# Altium schematic sheet SCH1.SchDoc
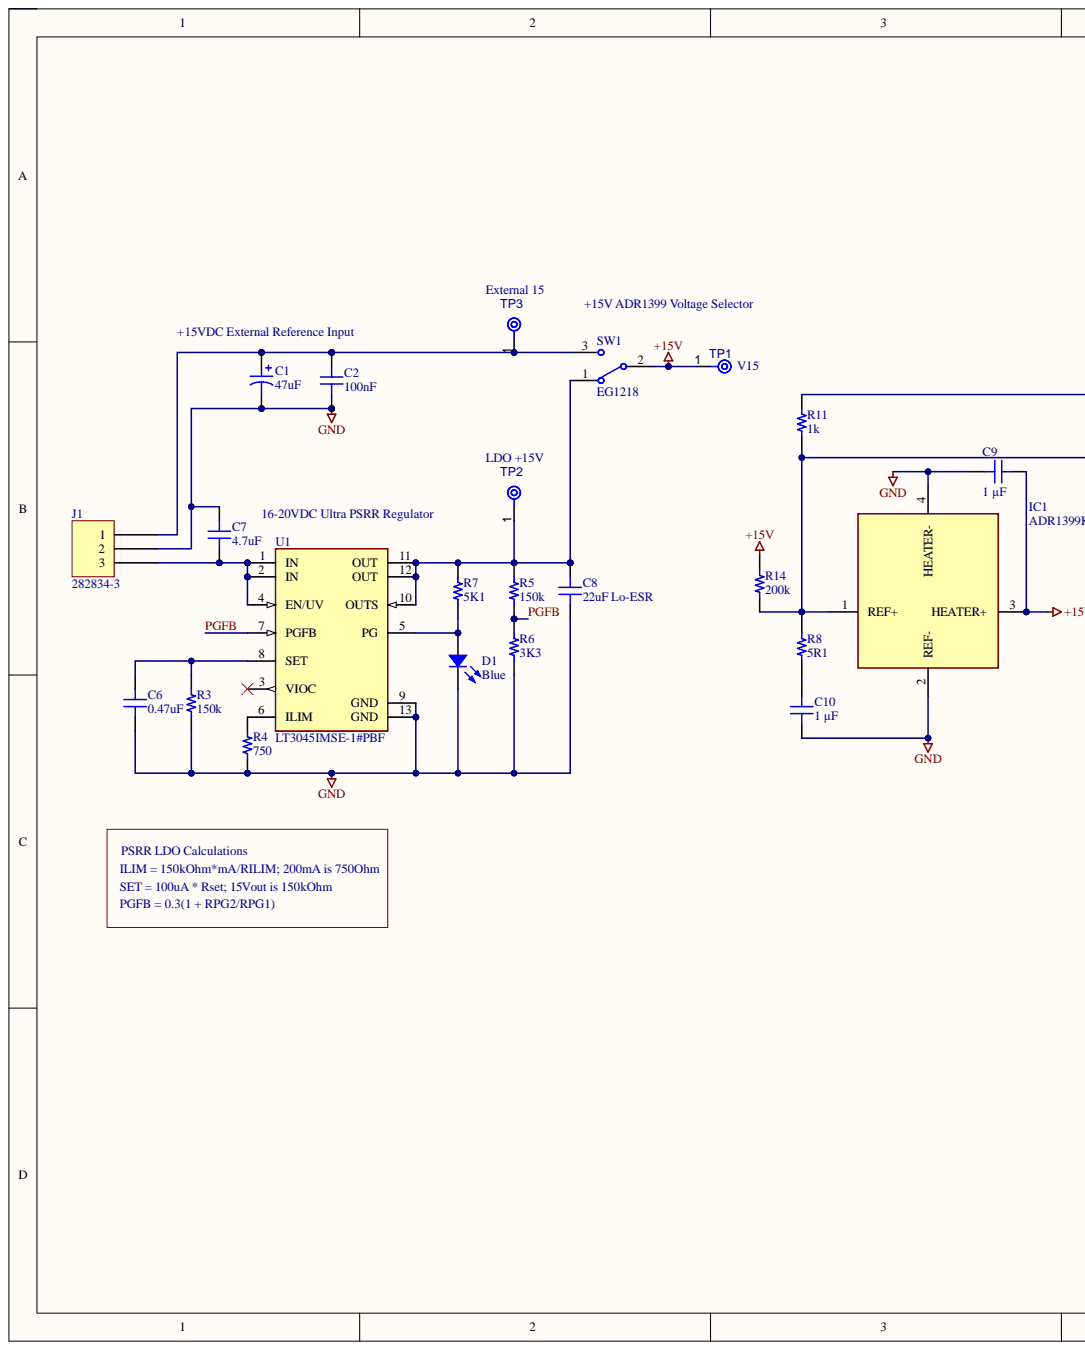
[diagram p0: SCH1.SchDoc, left]
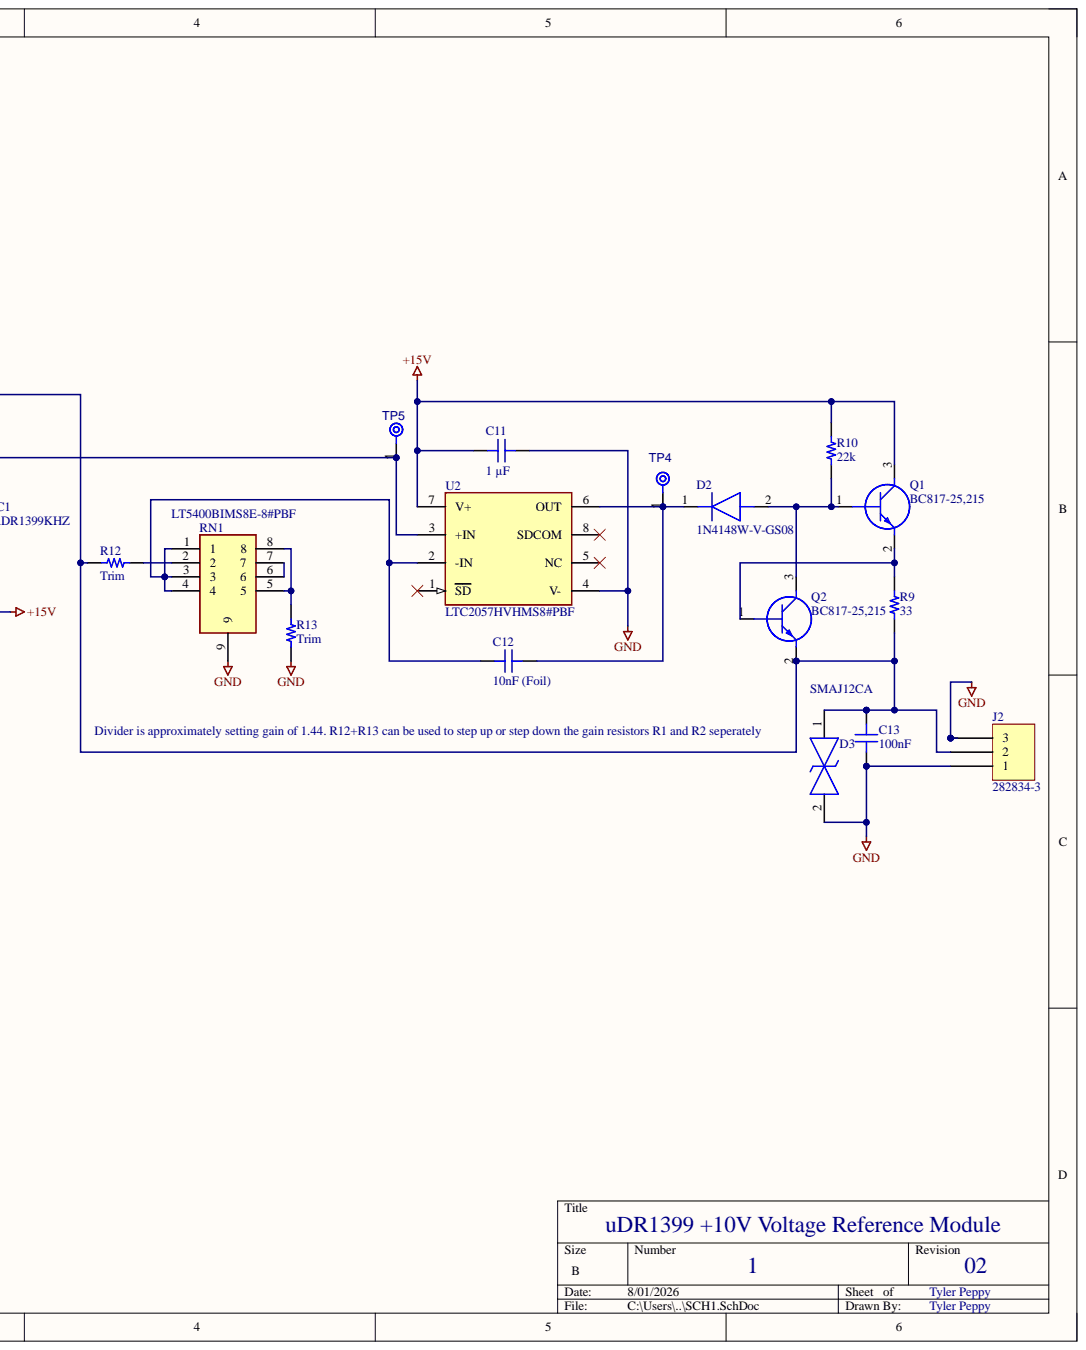
[diagram p1: SCH1.SchDoc, right]

## Parts
C1  47uF  [CAP ALSMD 8.3MM H10.5MM]
C2  Capacitance: 100nF  [CAP 0603_1608]  (hidden comment: 4.7 µF)
C6  Capacitance: 0.47uF  [CAP 0603_1608]  (hidden comment: 4.7 µF)
C7  Capacitance: 4.7uF  [CAP 0603_1608]  (hidden comment: 4.7 µF)
C8  Capacitance: 22uF Lo-ESR  [CAP 0603_1608]  (hidden comment: 4.7 µF)
C9  Capacitance: 1 µF  [CAP 0603_1608]  (hidden comment: 4.7 µF)
C10  Capacitance: 1 µF  [CAP 0603_1608]  (hidden comment: 4.7 µF)
C11  Capacitance: 1 µF  [CAP 0603_1608]  (hidden comment: 4.7 µF)
C12  Capacitance: 10nF (Foil)  [CAP 0603_1608]  (hidden comment: 4.7 µF)
C13  Capacitance: 100nF  [CAP 0603_1608]  (hidden comment: 4.7 µF)
D1  Blue  [LED 1206_3216 YELLOW]
D2  1N4148W-V-GS08  [1N4148WVGS08]
D3  SMAJ12CA  [DIONM5127X229N]
IC1  ADR1399KHZ  [ADR1399KHZ]
J1  282834-3  [TE 282834-3]
J2  282834-3  [TE 282834-3]
Q1  BC817-25,215  [BC81725215]
Q2  BC817-25,215  [BC81725215]
R3  150k  [RES 0603_1608]
R4  750  [RES 0603_1608]
R5  150k  [RES 0603_1608]
R6  3K3  [RES 0603_1608]
R7  5K1  [RES 0603_1608]
R8  5R1  [RES 0603_1608]
R9  33  [RES 0603_1608]
R10  22k  [RES 0603_1608]
R11  1k  [RES 0603_1608]
R12  Trim  [RES 0603_1608]
R13  Trim  [RES 0603_1608]
R14  200k  [RES 0603_1608]
RN1  LT5400BIMS8E-8#PBF  [SOP65P490X110-9N]
SW1  EG1218  [ESWI-EG1218_V]
TP1  TPAD6R  [TP06R]  (value not drawn)  (no BOM)
TP2  TPAD6R  [TP06R]  (value not drawn)  (no BOM)
TP3  TPAD6R  [TP06R]  (value not drawn)  (no BOM)
TP4  TPAD6R  [TP06R]  (value not drawn)  (no BOM)
TP5  TPAD6R  [TP06R]  (value not drawn)  (no BOM)
U1  LT3045IMSE-1#PBF  [FP-MSE-12-05-08-1666-MFG]
U2  LTC2057HVHMS8#PBF  [FP-MS8-8-05-08-1660-IPC_C]

## Nets
+15V: C9.2 C11.1 IC1.3(HEATER+) Q1.3 R10.2 R14.2 SW1.2 TP1.1 U2.7(V+)
GND: C1.2 C2.2 C6.2 C7.1 C8.2 C9.1 C10.1 C11.2 C13.1 D1.2 D3.2 IC1.2(REF-) IC1.4(HEATER-) J1.2 J2.1 J2.3 R3.1 R4.1 R6.1 R13.1 RN1.9 U1.9(GND) U1.13(GND) U2.4(V-)
PGFB: R5.1 R6.2 U1.7(PGFB)
NetC1_1: C1.1 C2.1 J1.1 SW1.3 TP3.1
NetC6_1: C6.1 R3.2 U1.8(SET)
NetC7_2: C7.2 J1.3 U1.1(IN) U1.2(IN) U1.4(EN/UV)
NetC8_1: C8.1 R5.2 R7.2 SW1.1 TP2.1 U1.10(OUTS) U1.11(OUT) U1.12(OUT)
NetC10_2: C10.2 R8.1
NetC12_1: C12.1 RN1.1 RN1.3 RN1.4 U2.2(-IN)
NetC12_2: C12.2 D2.1 TP4.1 U2.6(OUT)
NetC13_2: C13.2 D3.1 J2.2 Q2.2 R9.1 R11.2 R12.2
NetD1_1: D1.1 R7.1 U1.5(PG)
NetD2_2: D2.2 Q1.1 Q2.3 R10.1
NetIC1_1: IC1.1(REF+) R8.2 R11.1 R14.1 TP5.1 U2.3(+IN)
NetQ1_2: Q1.2 Q2.1 R9.2
NetR4_2: R4.2 U1.6(ILIM)
NetR12_1: R12.1 RN1.2
NetR13_2: R13.2 RN1.5 RN1.8
NetRN1_6: RN1.6 RN1.7

Not connected: U1 3(VIOC); U2 1(SD) 5(NC) 8(SDCOM)

## Library data of the parts
C1 = EMZS350ARA471MHA0G: CAP ALUM 470UF 20% 35V SMD; manufacturer: United Chemi-Con; Capacitance: 470 µF; Database Table Name: Capacitors - Aluminum - Electrolytic SMT; ESR (Equivalent Series Resistance): 80mOhm @ 100kHz; Lifetime @ Temp.: 2000 Hrs @ 105°C; Polarization: Polar; Ripple Current @ High Frequency: 850 mA @ 100 kHz; Ripple Current @ Low Frequency: 425 mA @ 120 Hz; Surface Mount Land Size: 0.327" L x 0.327" W (8.30mm x 8.30mm); value: 470 µF; Applications: Automotive; Component Kind: Standard; componentlink1description: Datasheet; componentlink1url: //media.digikey.com/pdf/Data%20Sheets/United%20Chemi-Con%20PDFs/MZS_Series_NPI.pdf; componentlink2description: DigiKey Link; componentlink2url: https://www.digikey.com/en/products/detail/united-chemi-con/EMZS350ARA471MHA0G/8637079; Device Package: Radial, Can - SMD; Height - Seated (Max): 0.413" (10.50mm); lastupdated: 6/3/2024 8:15:48 PM; Library Name: Celestial Altium Library - altium_library.DbLib; Lifecycle Status: Active; Minimum Order: 1; Mounting Type: Surface Mount; Operating Temperature: -55°C ~ 105°C; Package / Case: Radial, Can - SMD; packaging: TapeAndReel; Part Number: EMZS350ARA471MHA0G; Part Status: Active; partid: 7526; price: 0.40; Ratings: AEC-Q200; series: Alchip™- MZS; Size / Dimension: 0.315" Dia (8.00mm); Supplier 1: DigiKey; Supplier Part Number 1: 565-5123-1-ND; Tolerance: ±20%; Voltage - Rated: 35 V
C2 C6-C13 = CL10A475KA8NQNC: CAP CER 4.7UF 25V X5R 0603; manufacturer: Samsung Electro-Mechanics; Database Table Name: Capacitors - Ceramic - 0603; Tolerance: ±10%; Applications: General Purpose; Component Kind: Standard; componentlink1description: Datasheet; componentlink1url: http://www.samsungsem.com/kr/support/product-search/mlcc/CL10A475KA8NQNC.jsp; componentlink2description: DigiKey Link; componentlink2url: https://www.digikey.com/en/products/detail/samsung-electro-mechanics/CL10A475KA8NQNC/3887558; Device Package: 0603 (1608 Metric); lastupdated: 2/9/2024 8:10:30 PM; Library Name: Celestial Altium Library - altium_library.DbLib; Lifecycle Status: Active; Minimum Order: 1; Mounting Type: Surface Mount, MLCC; Operating Temperature: -55°C ~ 85°C; Package / Case: 0603 (1608 Metric); packaging: TapeAndReel; Part Number: CL10A475KA8NQNC; Part Status: Active; partid: 2349; price: 0.06; series: CL; Size / Dimension: 0.063" L x 0.031" W (1.60mm x 0.80mm); Supplier 1: DigiKey; Supplier Part Number 1: 1276-1900-1-ND; Temperature Coefficient: X5R; Thickness (Max): 0.035" (0.90mm); value: 4.7 µF; Voltage - Rated: 25V
D1 = 5990240007F: LED YELLOW 1206 SMD; Manufacturer: Dialight; Configuration: Standard; Current - Test: 20mA; Database Table Name: LED - Indication; Lens Color: 0.80mm; Lens Size: 2.00mm x 1.60mm; Lens Style: Rectangle with Flat Top; Millicandela Rating: 126mcd; Viewing Angle: 140°; Voltage - Forward (Vf) (Typ): 2.1V; Wavelength - Dominant: 590nm; Color: Yellow; Component Kind: Standard; ComponentLink1Description: Datasheet; ComponentLink1URL: http://www.dialightsignalsandcomponents.com/Assets/Brochures_And_Catalogs/Indication/CBI_SMD_new/Dialight_CBI_data_599-1206_Apr2018.pdf; ComponentLink2Description: DigiKey Link; ComponentLink2URL: https://www.digikey.com/en/products/detail/dialight/5990240007F/9385418; Device Package: 1206 (3216 Metric); Height (Max): 0.80mm; LastUpdated: 6/3/2024 8:01:38 PM; Library Name: Celestial Altium Library - altium_library.DbLib; Lifecycle Status: Active; Minimum Order: 1; Mounting Type: Surface Mount; Package / Case: 1206 (3216 Metric); Packaging: TapeAndReel; Part Number: 5990240007F; Part Status: Active; PartId: 1; Price: 0.04; Series: 599; Size / Dimension: 3.20mm L x 1.60mm W; Supplier 1: DigiKey; Supplier Device Package: 1206; Supplier Part Number 1: 350-4363-1-ND; Value: Yellow
D2 = 1N4148W-V-GS08: Diode; Manufacturer_Name: Vishay; Arrow Part Number: 1N4148W-V-GS08; Arrow Price/Stock: https://www.arrow.com/en/products/1n4148w-v-gs08/vishay; Component Kind: Standard; Datasheet Link: https://us.rs-online.com/m/d/a2789c8794cb0c42ced29f2dd8571f3c.pdf; Height: 1.35mm; Manufacturer_Part_Number: 1N4148W-V-GS08; Mouser Part Number: 625-1N4148W-V; Mouser Price/Stock: https://www.mouser.co.uk/ProductDetail/Vishay-Semiconductors/1N4148W-V-GS08?qs=TNTIDjy6APrS%2Fdgq1RSs3A%3D%3D
D3 = SMAJ12CA: TVS Diode (Bi-directional); Manufacturer_Name: Bourns; Arrow Part Number: SMAJ12CA; Arrow Price/Stock: https://www.arrow.com/en/products/smaj12ca/bourns.html?utm_currency=USD&region=nac; Component Kind: Standard; Datasheet Link: https://www.bourns.com/data/global/pdfs/SMAJ.pdf; Height: 2.29mm; Manufacturer_Part_Number: SMAJ12CA; Mouser Part Number: 652-SMAJ12CA; Mouser Price/Stock: https://www.mouser.co.uk/ProductDetail/Bourns/SMAJ12CA?qs=A3H9pljuJ8QXtI4Ziid8eA%3D%3D
IC1 = ADR1399KHZ: Integrated Circuit; Manufacturer_Name: Analog Devices; Arrow Part Number: ADR1399KHZ; Arrow Price/Stock: https://www.arrow.com/en/products/adr1399khz/analog-devices?utm_currency=USD&region=nac; Component Kind: Standard; Datasheet Link: https://www.analog.com/ADR1399/datasheet; Height: 2.667mm; Manufacturer_Part_Number: ADR1399KHZ; Mouser Part Number: 584-ADR1399KHZ; Mouser Price/Stock: https://www.mouser.co.uk/ProductDetail/Analog-Devices/ADR1399KHZ?qs=Rp5uXu7WBW%252BnLiU1PGvLXA%3D%3D
J1 J2 = 282834-3: TERM BLK 3P SIDE ENT 2.54MM PCB; Manufacturer: TE Connectivity AMP Connectors; Current: 10A; Database Table Name: Connectors - Terminal Blocks - Wire to Board; Mating Orientation: Horizontal with Board; Number of Levels: 1; Pitch: 0.100" (2.54mm); Positions Per Level: 3; Voltage: 150V; Wire Gauge: 16-30 AWG; Wire Termination: Screw - Rising Cage Clamp; Color: Green; Component Kind: Standard; ComponentLink1Description: Datasheet; ComponentLink1URL: https://www.te.com/commerce/DocumentDelivery/DDEController?Action=srchrtrv&DocNm=282834&DocType=Customer+Drawing&DocLang=English; ComponentLink2Description: DigiKey Link; ComponentLink2URL: http://digikey.com/product-detail/en/te-connectivity-amp-connectors/282834-3/A98334-ND/1153264; LastUpdated: 1/15/2020 4:15:50 AM; Library Name: Celestial Altium Library - altium_library.DbLib; Lifecycle Status: Active; Minimum Order: 1; Mounting Type: Through Hole; Packaging: Bulk; Part Number: 282834-3; Part Status: Active; Price: 2.01; Series: Buchanan; Supplier 1: DigiKey; Supplier Part Number 1: A98334-ND
Q1 Q2 = BC817-25,215: Transistor BJT NPN; Manufacturer_Name: Nexperia; Arrow Part Number: BC817-25,215; Arrow Price/Stock: https://www.arrow.com/en/products/bc817-25215/nexperia?region=nac; Component Kind: Standard; Datasheet Link: https://assets.nexperia.com/documents/data-sheet/BC817_SER.pdf; Height: 1.1mm; Manufacturer_Part_Number: BC817-25,215; Mouser Part Number: 771-BC817-25-T/R; Mouser Price/Stock: https://www.mouser.co.uk/ProductDetail/Nexperia/BC817-25215?qs=me8TqzrmIYUj67JkTBzlfg%3D%3D
R3-R14 = RL0603FR-070R01L: RES 0.01 OHM 1% 1/10W 0603; Manufacturer: Yageo; Database Table Name: Resistors - Surface Mount - 0603; Power (Watts): 0.1W, 1/10W; Resistance: 10 mOhms; Temperature Coefficient: ±1500ppm/°C; Component Kind: Standard; ComponentLink1Description: Datasheet; ComponentLink1URL: http://www.yageo.com/documents/recent/PYu-RL_Group_521_RoHS_L_2.pdf; ComponentLink2Description: DigiKey Link; ComponentLink2URL: https://www.digikey.com/en/products/detail/yageo/RL0603FR-070R01L/3885501; Composition: Thick Film; Device Package: 0603 (1608 Metric); Features: Current Sense; Height - Seated (Max): 0.022" (0.55mm); LastUpdated: 4/6/2026 7:44:01 PM; Library Name: Celestial Altium Library - altium_library.DbLib; Lifecycle Status: Active; Minimum Order: 1; Number of Terminations: 2; Operating Temperature: -55°C ~ 155°C; Package / Case: 0603 (1608 Metric); Packaging: TapeAndReel; Part Number: RL0603FR-070R01L; Part Status: Active; PartId: 4869; Price: 0.09; Ratings: AEC-Q200; Series: RL; Size / Dimension: 0.063" L x 0.031" W (1.60mm x 0.80mm); Supplier 1: DigiKey; Supplier Device Package: 0603; Supplier Part Number 1: 311-.01QCT-ND; Tolerance: ±1%
  R3 R5: Value: 150k
  R4: Value: 750
  R6: Value: 3K3
  R7: Value: 5K1
  R8: Value: 5R1
  R9: Value: 33
  R10: Value: 22k
  R11: Value: 1k
  R12 R13: Value: Trim
  R14: Value: 200k
RN1 = LT5400BIMS8E-8#PBF: Integrated Circuit; Manufacturer_Name: Analog Devices; Arrow Part Number: LT5400BIMS8E-8#PBF; Arrow Price/Stock: https://www.arrow.com/en/products/lt5400bims8e-8pbf/linear-technology; Component Kind: Standard; Datasheet Link: https://datasheet.datasheetarchive.com/originals/distributors/DKDS-14/268906.pdf; Height: 1.1mm; Manufacturer_Part_Number: LT5400BIMS8E-8#PBF; Mouser Part Number: 584-5400BIMS8E-8PBF; Mouser Price/Stock: https://www.mouser.co.uk/ProductDetail/Analog-Devices/LT5400BIMS8E-8PBF?qs=oahfZPh6IAIYY0b05xfkyw%3D%3D
SW1 = CMP-1696-00002-1: Slide Switch, 500 V, -20 to 70 degC, 3-Pin THD, RoHS; Case\Package: SOT-23-5; Dropout Voltage: 300 mV; Max Input Voltage: 8 V; Max Ouput Current: 150 mA; Max Power Dissipation: 250 mW; Min Input Voltage: 4.3 V; Nominal Output Voltage: 3.3 V; Outputs: 1; Component Kind: Standard; ComponentLink1Description: Manufacturer URL; ComponentLink1URL: http://www.e-switch.ch/en/; ComponentLink2Description: Datasheet; ComponentLink2URL: https://www.e-switch.com/system/asset/product_line/data_sheet/119/EG.pdf; DatasheetVersion: Oct-15; Height: 1.3 mm; Length: 3 mm; Library Name: Altium Content Vault; Max Operating Temperature: 85 degC; Min Operating Temperature: -40 degC; Mount: Surface Mount; Output Current: 150 mA; Output Voltage: 3.3 V; Package Quantity: 3000; PackageDescription: 3-Pin Through Hole Device, Body 11.6 x 4 mm, Pitch 2.5 mm; PackageReference: EG1218; Packaging: Tape and Reel; Pins: 5; Power Rating: 250 mW; Radiation Hardening: No; REACH SVHC: No SVHC; RoHSCompliant: true; Width: 1.8 mm
TP1-TP5 = CMP-00028-00002-2: 0.6mm round; Pins: 1; Library Name: Tyler Peppy
U1 = CMP-09138-000004-1: IC REG LINEAR 0V 500MA 12MSOP; Manufacturer: Analog Devices; Obsolete Part: false; Accuracy Percentage: 1%; Dropout Voltage: 260mV; Max Junction Temp: +150°C; Max Supply Voltage: 20V; Min Supply Voltage: 2V; Nominal Supply Current: 0.3uA; Number Of Outputs: 1; Output Type: AdjustableProgrammable; Output Voltage: 0-15V; Standoff Height: 0.0508mm; Automotive: No; Category: IC; Component Kind: Standard; ComponentLink2Description: Datasheet; ComponentLink2URL: https://www.analog.com/media/en/technical-documentation/data-sheets/30451fa.pdf; Device Class L1: Integrated Circuits (ICs); Device Class L2: Power Management ICs; Device Class L3: Voltage Regulators - Linear; Digikey Description: IC REG LINEAR 0V 500MA 12MSOP; Height: 1.1mm; Ipc Land Pattern Name: SOP65P490X110-12; Lead Free: Yes; Library Name: Altium Content Vault; Manufacturer Part Number: LT3045IMSE-1#PBF; Octopart: https://octopart.com/lt3045imse-1%23pbf-analog+devices-88963539; Output Current: 500mA; Package: MSE-12-05-08-1666; RoHS: TRUE; Temperature: -40°C to +125°C; Temperature Range High: +125°C; Temperature Range Low: -40°C
U2 = CMP-09116-000271-1: IC OPAMP ZERO-DRIFT 1 CIRC 8MSOP; Bandwidth: 1.5MHz; Input Bias Current: 30pA; Input Offset Voltage (Vos): 0.5uV; Number of Amplifiers: 1; Operating Supply Current: 900uA; Output Current per Channel: 30mA; Slew Rate: 0.45V/µs; Case/Package: MSOP; Component Kind: Standard; Gain Bandwidth Product: 1.5MHz; Lead Free: Lead Free; Library Name: Altium Content Vault; Max Operating Temperature: 125°C; Min Operating Temperature: -40°C; Mount: Surface Mount; Number of Circuits: 1; Number of Pins: 8; Package Quantity: 100; Packaging: Rail/Tube; REACH SVHC: No SVHC; RoHS Compliant: Yes
